# Altium schematic sheet EIT_MCU_1.SchDoc
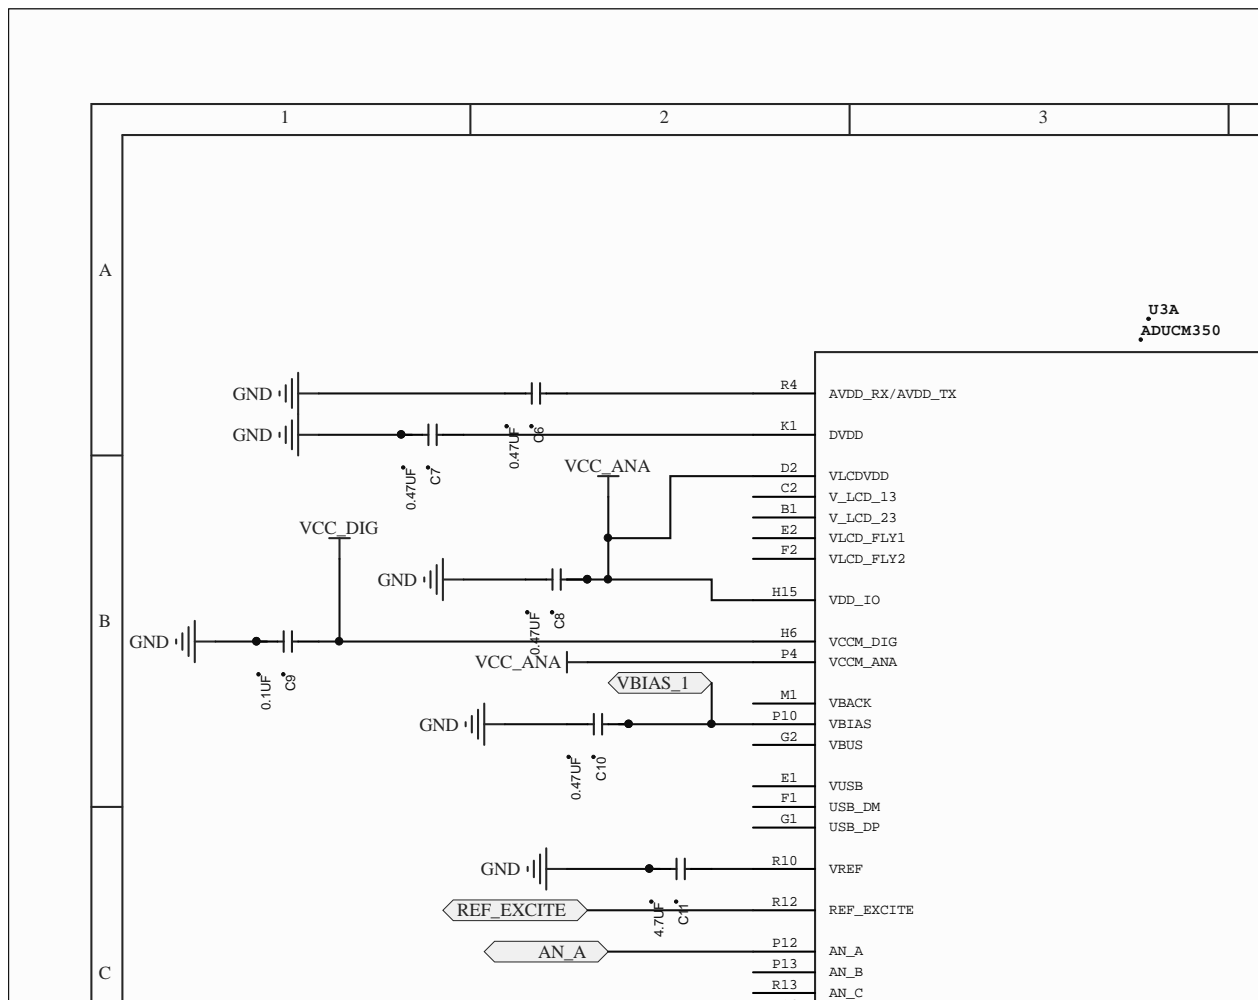
[diagram p0: EIT_MCU_1.SchDoc, top left]
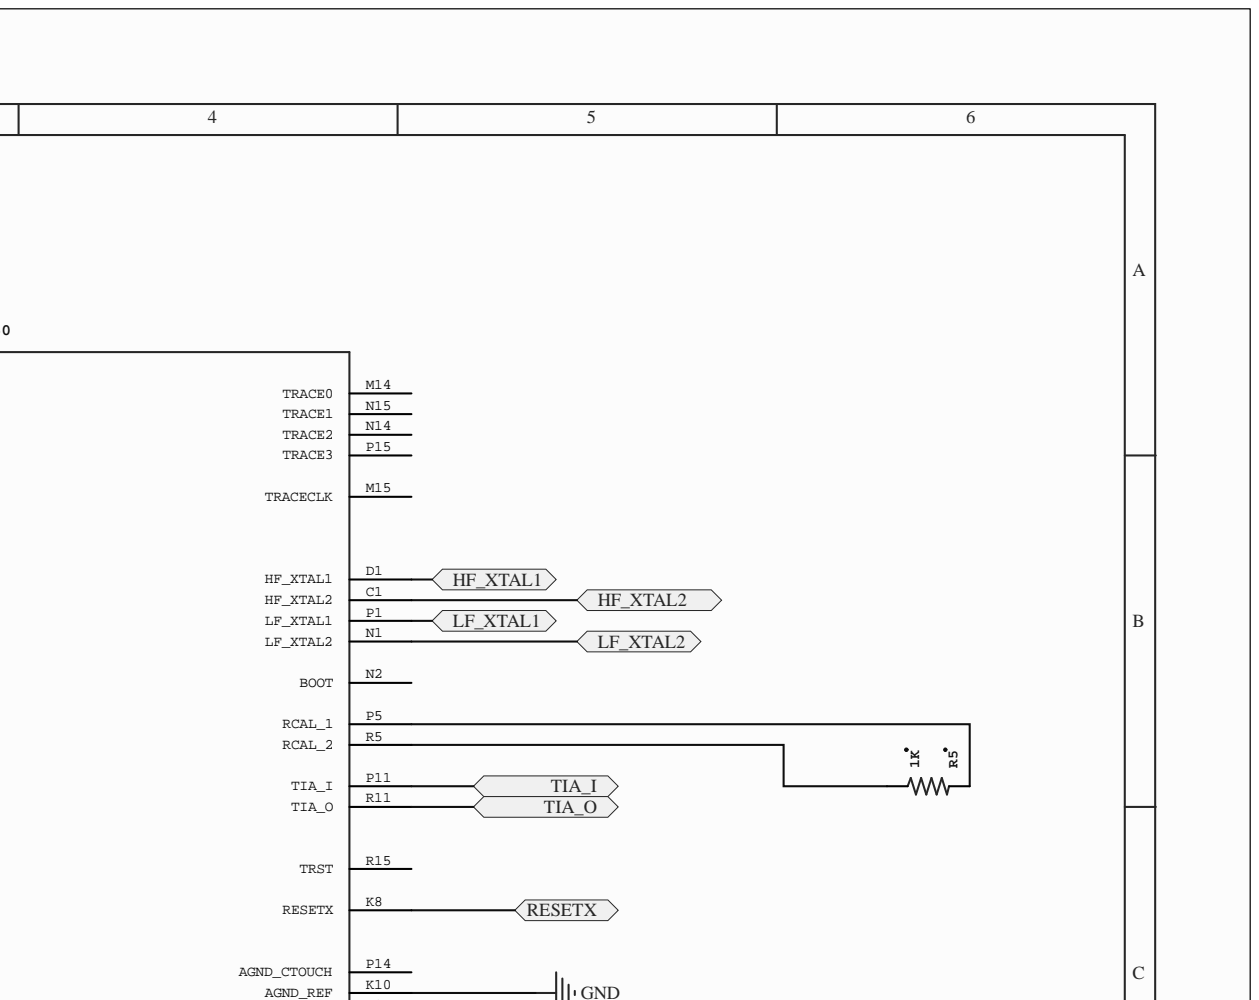
[diagram p1: EIT_MCU_1.SchDoc, top right]
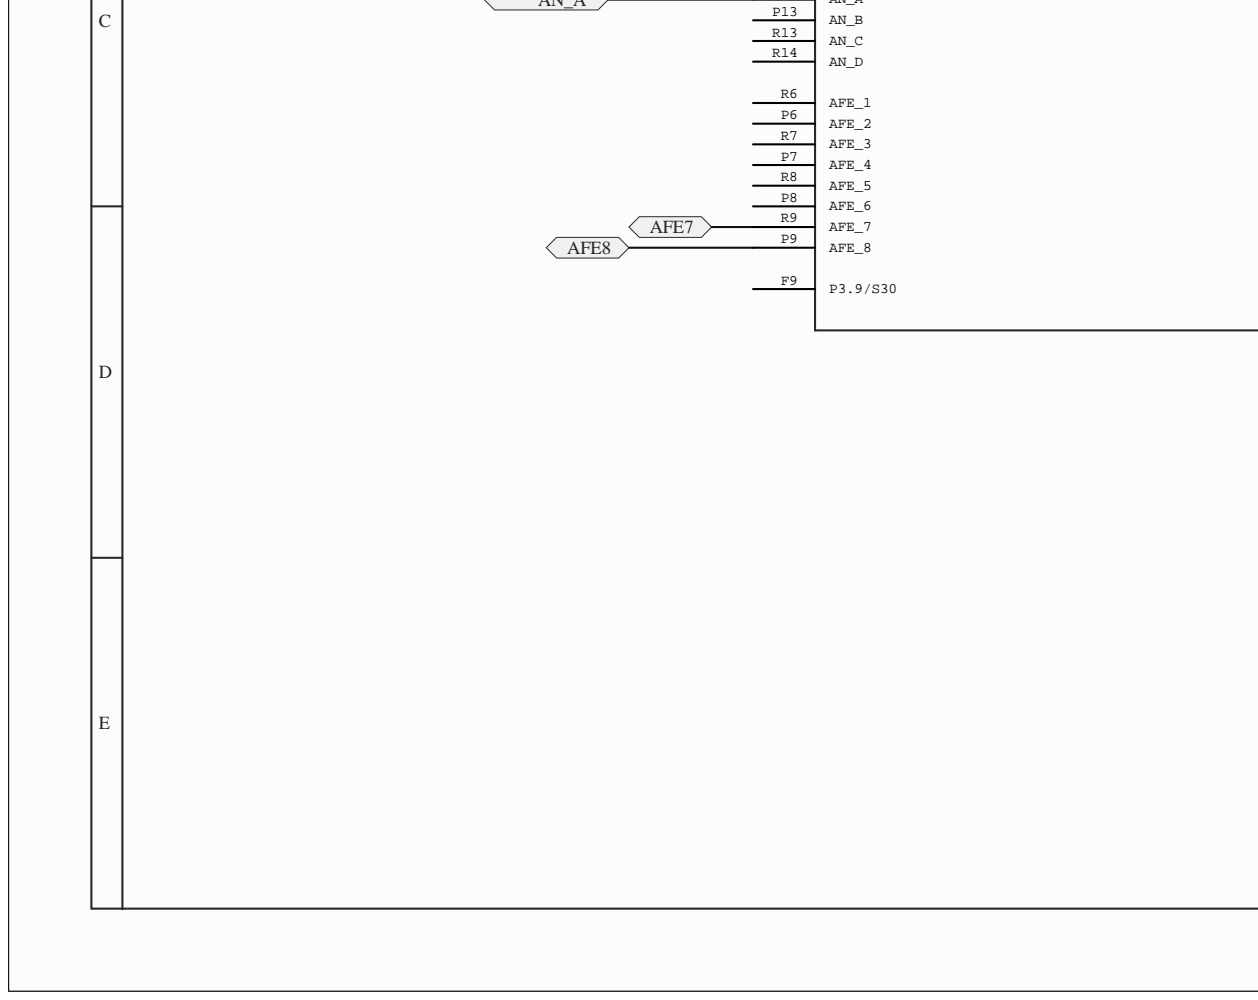
[diagram p2: EIT_MCU_1.SchDoc, bottom left]
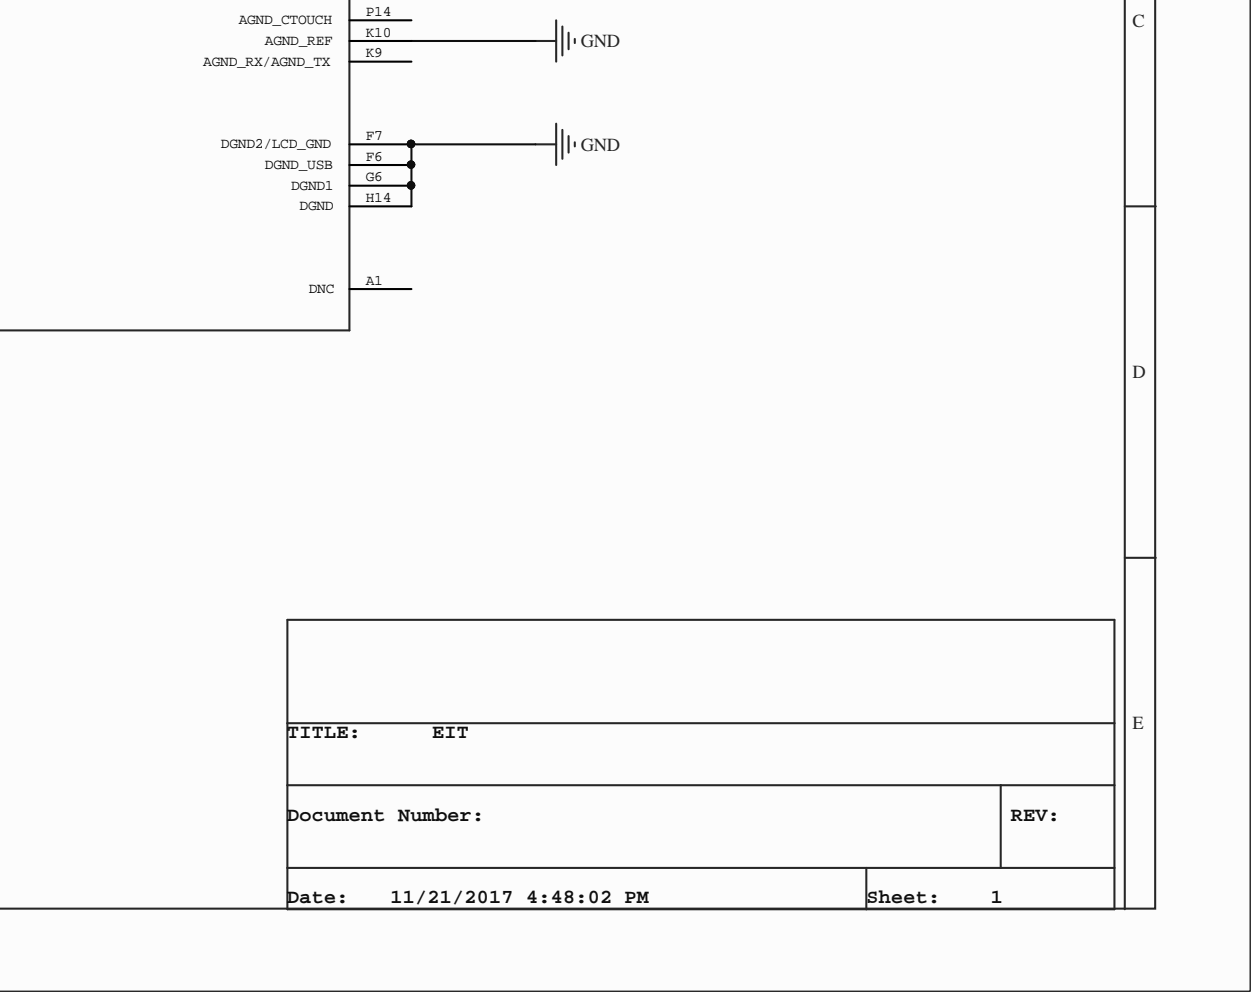
[diagram p3: EIT_MCU_1.SchDoc, bottom right]

## Parts
C6  0.47UF  [CAP 0402/1005]
C7  0.47UF  [CAP 0402/1005]
C8  0.47UF  [CAP 0402/1005]
C9  0.1UF  [CAP 0402/1005]
C10  0.47UF  [CAP 0402/1005]
C11  4.7UF  [CAP 0402/1005]
R5  1K  [RES_1608X55]
U3  ADUCM350  [ADUCM350]  (part A)

## Ports
AFE7, AFE8, AN_A, HF_XTAL1, HF_XTAL2, LF_XTAL1, LF_XTAL2, REF_EXCITE, RESETX, TIA_I, TIA_O, VBIAS_1

## Nets
GND: C6.1 C7.1 C8.1 C9.1 C10.1 C11.1 U3.F6(DGND_USB) U3.F7(DGND2/LCD_GND) U3.G6(DGND1) U3.H14(DGND) U3.K10(AGND_REF)
VCC_ANA: C8.2 U3.D2(VLCDVDD) U3.H15(VDD_IO) U3.P4(VCCM_ANA)
VCC_DIG: C9.2 U3.H6(VCCM_DIG)
AFE7: U3.R9(AFE_7) ; port AFE7
AFE8: U3.P9(AFE_8) ; port AFE8
AN_A: U3.P12(AN_A) ; port AN_A
HF_XTAL1: U3.D1(HF_XTAL1) ; port HF_XTAL1
HF_XTAL2: U3.C1(HF_XTAL2) ; port HF_XTAL2
LF_XTAL1: U3.P1(LF_XTAL1) ; port LF_XTAL1
LF_XTAL2: U3.N1(LF_XTAL2) ; port LF_XTAL2
REF_EXCITE: U3.R12(REF_EXCITE) ; port REF_EXCITE
RESETX: U3.K8(RESETX) ; port RESETX
TIA_I: U3.P11(TIA_I) ; port TIA_I
TIA_O: U3.R11(TIA_O) ; port TIA_O
VBIAS_1: C10.2 U3.P10(VBIAS) ; port VBIAS_1
NetC6_2: C6.2 U3.R4(AVDD_RX/AVDD_TX)
NetC7_2: C7.2 U3.K1(DVDD)
NetC11_2: C11.2 U3.R10(VREF)
NetR5_1: R5.1 U3.P5(RCAL_1)
NetR5_2: R5.2 U3.R5(RCAL_2)

Not connected: U3 A1(DNC) B1(V_LCD_23) C2(V_LCD_13) E1(VUSB) E2(VLCD_FLY1) F1(USB_DM) F2(VLCD_FLY2) F9(P3.9/S30) G1(USB_DP) G2(VBUS) K9(AGND_RX/AGND_TX) M1(VBACK) M14(TRACE0) M15(TRACECLK) N2(BOOT) N14(TRACE2) N15(TRACE1) P6(AFE_2) P7(AFE_4) P8(AFE_6) P13(AN_B) P14(AGND_CTOUCH) P15(TRACE3) R6(AFE_1) R7(AFE_3) R8(AFE_5) R13(AN_C) R14(AN_D) R15(TRST)

## Library data of the parts
C6-C8 C10 = CAP_0402_0.47UF: CAP CER 0.47UF 4V X5R 0402; Manufacturer 1: KEMET; Manufacturer Part Number 1: C0402C474K7PAC7867; Vendor: DIGIKEY; Component Kind: Standard; Supplier 1: Digi-Key; Supplier Part Number 1: 399-14917-6-ND; Value: 0.47UF; Library Name: EIT_lib.SchLib
C9 = CAP_0402_0.1UF: CAP CER 0.1UF 4V X5R 0402 10% tolerance; Manufacturer 1: KEMET; Manufacturer Part Number 1: C0402C104K7PAC7867; Vendor: DIGIKEY; Component Kind: Standard; Supplier 1: Digi-Key; Supplier Part Number 1: 399-14871-1-ND; Value: 0.1UF; Library Name: EIT_lib.SchLib
C11 = CAP_0402_4.7UF: CAP CER 4.7UF 4V X5R 0402; Manufacturer 1: Taiyo Yuden; Manufacturer Part Number 1: GRM155R60G475ME87D; Vendor: DIGIKEY; Component Kind: Standard; Supplier 1: Digi-Key; Supplier Part Number 1: 587-1966-6-ND; Value: 4.7UF; Library Name: EIT_lib.SchLib
R5 = RES_0603_1K: 1kOhm RES_0603; Manufacturer 1: Yageo; Manufacturer Part Number 1: RC0603FR-071KL; PARTNO: MCR03ERTF5600; DeviceName: -0603(1608METRIC); DeviceSetName: RESISTOR-US; GateName_1: G$1; Component Kind: Standard; OC_NEWARK: 52K8621; Supplier 1: Digi-Key; Supplier Part Number 1: 311-1.00KHRCT-ND; SymbolName_1: RESISTOR-US; Value: 1K; Library Name: EIT_lib.SchLib
U3 = ADUCM350: ARM® Cortex®-M3 ADuCM Microcontroller IC 32-Bit 16MHz 384KB (384K x 8) FLASH 120-CSPBGA (8x8); Manufacturer 1: Analog Devices Inc.; Manufacturer Part Number 1: ADUCM350BBCZ; GateName_1: A; GateName_2: B; SymbolName_1: ADUCM350BBCZ_A; SymbolName_2: ADUCM350BBCZ_B; Component Kind: Standard; DeviceSetName: ADUCM350; OC_NEWARK: 40Y6255; Supplier 1: Digi-Key; Supplier Part Number 1: ADUCM350BBCZ-ND; Library Name: EIT_lib.SchLib; LibraryName: BiomedicalImagingLibrary
